# Altium schematic sheet OUT.SchDoc(OUT)
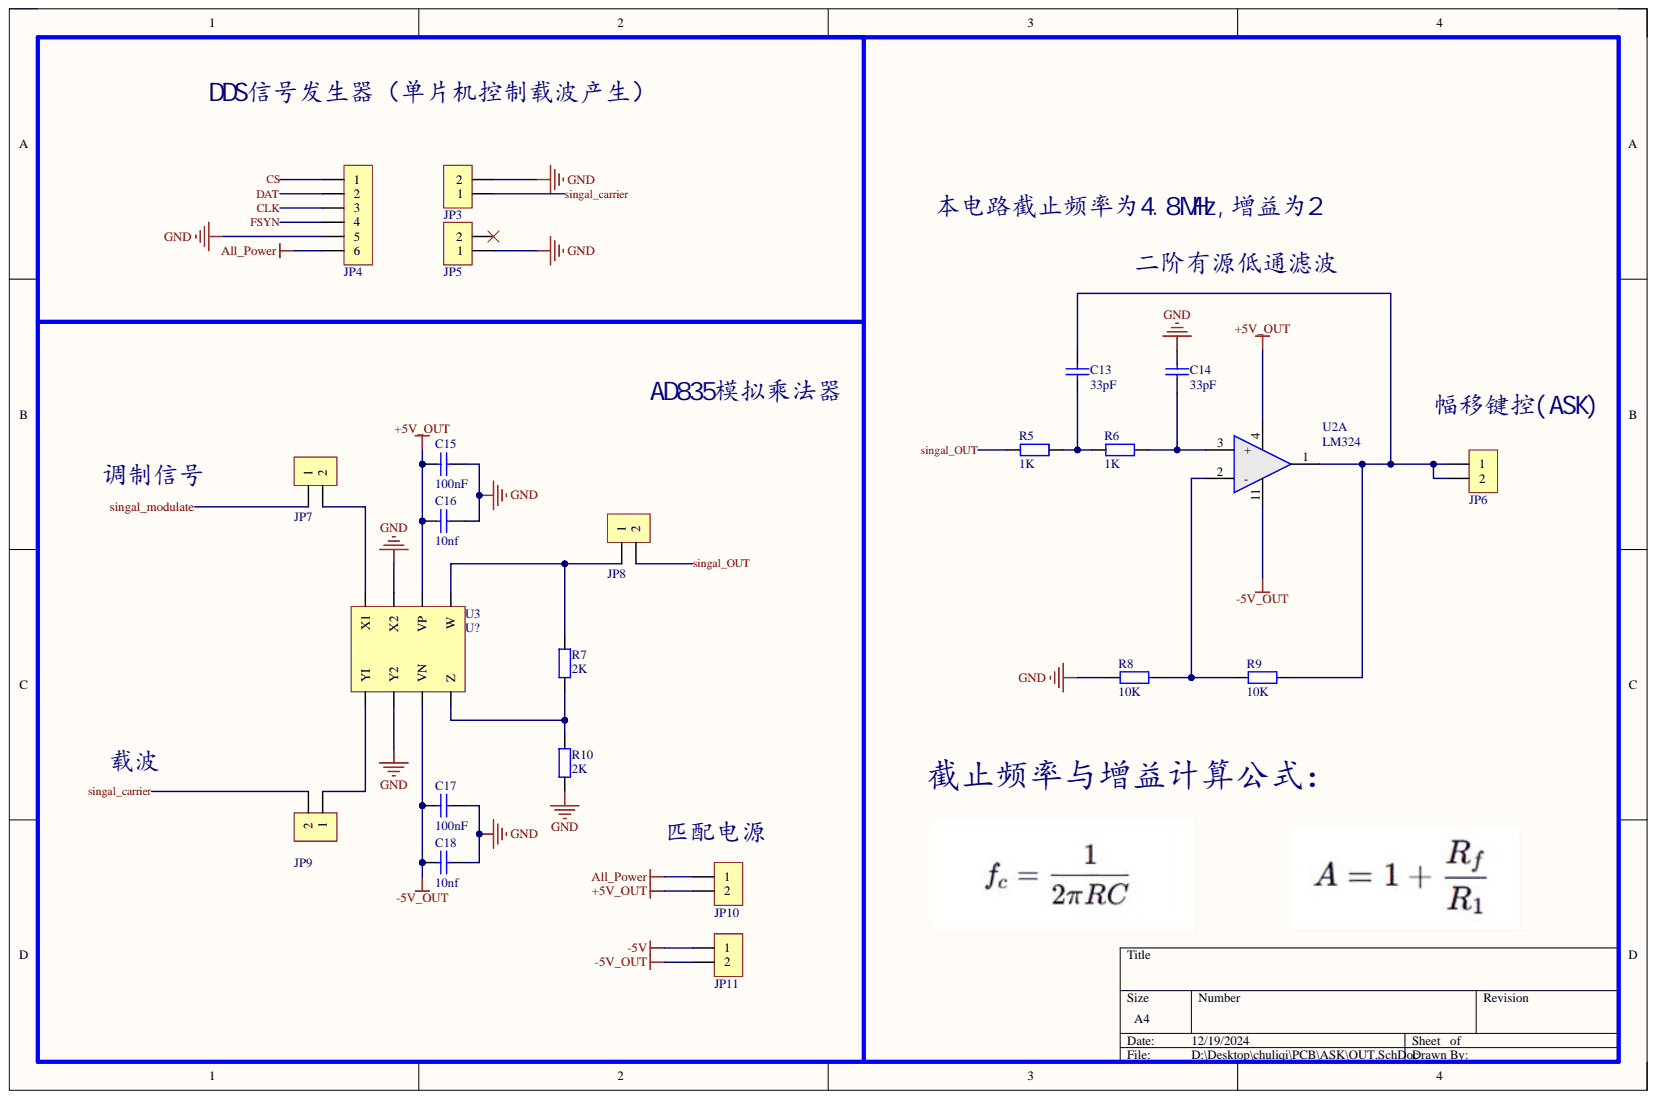
[diagram p0: OUT.SchDoc]

## Parts
C13  33pF  [C0603_L]
C14  33pF  [C0603_L]
C15  100nF  [C0603_L]
C16  10nf  [C0603_L]
C17  100nF  [C0603_L]
C18  10nf  [C0603_L]
JP3  HDR-1X2  [XH2.54-WI-2P]  (value not drawn)
JP4  HDR-1X6  [XH2.54-WI-6P]  (value not drawn)
JP5  HDR-1X2  [XH2.54-WI-2P]  (value not drawn)
JP6  HDR-1X2  [XH2.54-WI-2P]  (value not drawn)
JP7  HDR-1X2  [XH2.54-WI-2P]  (value not drawn)
JP8  HDR-1X2  [XH2.54-WI-2P]  (value not drawn)
JP9  HDR-1X2  [XH2.54-WI-2P]  (value not drawn)
JP10  HDR-1X2  [HDR2.54-LI-2P]  (value not drawn)
JP11  HDR-1X2  [HDR2.54-LI-2P]  (value not drawn)
R5  1K  [R0603_L]
R6  1K  [R0603_L]
R7  2K  [R0603_L]
R8  10K  [R0603_L]
R9  10K  [R0603_L]
R10  2K  [R0603_L]
U2  LM324  [SOP14_M]  (part A)
U3  U?  [SOIC-8L]

## Nets
+5V_OUT: C15.1 C16.2 JP10.2 U2.4 U3.6(VP)
-5V: JP11.1
-5V_OUT: C17.1 C18.2 JP11.2 U2.11 U3.3(VN)
All_Power: JP4.6 JP10.1
GND: C14.2 C15.2 C16.1 C17.2 C18.1 JP3.2 JP4.5 JP5.1 R8.2 R10.1 U3.2(Y2) U3.7(X2)
CLK: JP4.3
CS: JP4.1
DAT: JP4.2
FSYN: JP4.4
singal_carrier: JP3.1 JP9.2
singal_modulate: JP7.1
singal_OUT: JP8.2 R5.1
NetC13_1: C13.1 R5.2 R6.1
NetC13_2: C13.2 JP6.1 JP6.2 R9.2 U2.1
NetC14_1: C14.1 R6.2 U2.3(+)
NetJP7_2: JP7.2 U3.8(X1)
NetJP8_1: JP8.1 R7.1 U3.5(W)
NetJP9_1: JP9.1 U3.1(YI)
NetR7_2: R7.2 R10.2 U3.4(Z)
NetR8_1: R8.1 R9.1 U2.2(-)

Not connected: JP5 2

## Library data of the parts
C13-C15 C17 = C: 贴片电容/MLCC电容; BOM名称: 无极性贴片电容; 当前库版本V2022.11.14 @淘宝卖家: 最新版本发布https://shop463601444.taobao.com; Component Kind: Standard; Library Name: 0.1 - 电阻-电容-电感-保险丝-晶振-晶体-磁珠-电位器-法拉电容-馈通电容.SchLib
C16 C18 = C_3: 贴片电容; BOM名称: 无极性贴片电容; 联系卖家，免费查找封装: 唯一出售店铺网址https://shop463601444.taobao.com; Component Kind: Standard; Library Name: cdud开发板.SCHLIB
JP3 JP5-JP9 = Header 2: 2P接插件; 版权归属: 封装库来自淘宝 ID：黄晶宝石; BOM名称: 2P接插件; Component Kind: Standard; Library Name: 5.4 - 接插件-脚距2.54 (100mil) .SchLib
JP4 = Header 6: 6P接插件; 学习资源: 最新版本发布https://item.taobao.com/item.htm?id=566775463719; 版权归属: 封装库来自淘宝 ID：黄晶宝石; BOM名称: 6P接插件; Component Kind: Standard; Library Name: 5.4 - 接插件-脚距2.54 (100mil) .SchLib
JP10 JP11 = Header 2: 2P接插件; 封装库来自淘宝卖家ID：黄晶宝石: 其他店铺购入均为盗版封装库，请立即申请退款，本店现金奖励50~200元; 联系卖家，免费查找封装: 唯一出售店铺网址https://shop463601444.taobao.com; BOM名称: 2P接插件; Component Kind: Standard; Library Name: 5.5 - 2.54mm连接器(KF2EDGK_XH2.54_2.54排针排母).SchLib
R5-R10 = R: 贴片电阻; 联系下单店铺卖家 免费帮你查找封装: 联系下单店铺卖家 免费技术支持; BOM名称: 贴片电阻; Component Kind: Standard; Library Name: 0.1 - 电阻-电容-电感-保险丝-晶振-晶体-磁珠-电位器-法拉电容-馈通电容.SchLib
U2 = LM324: 4运放; Component Kind: Standard; Library Name: 1.0 - 常见芯片.SchLib
U3 = AD835ARZ: 四象限模拟乘法器; Component Kind: Standard; Library Name: mylibrary.SchLib
